# Altium schematic sheet FTDI.SchDoc(FTDI)
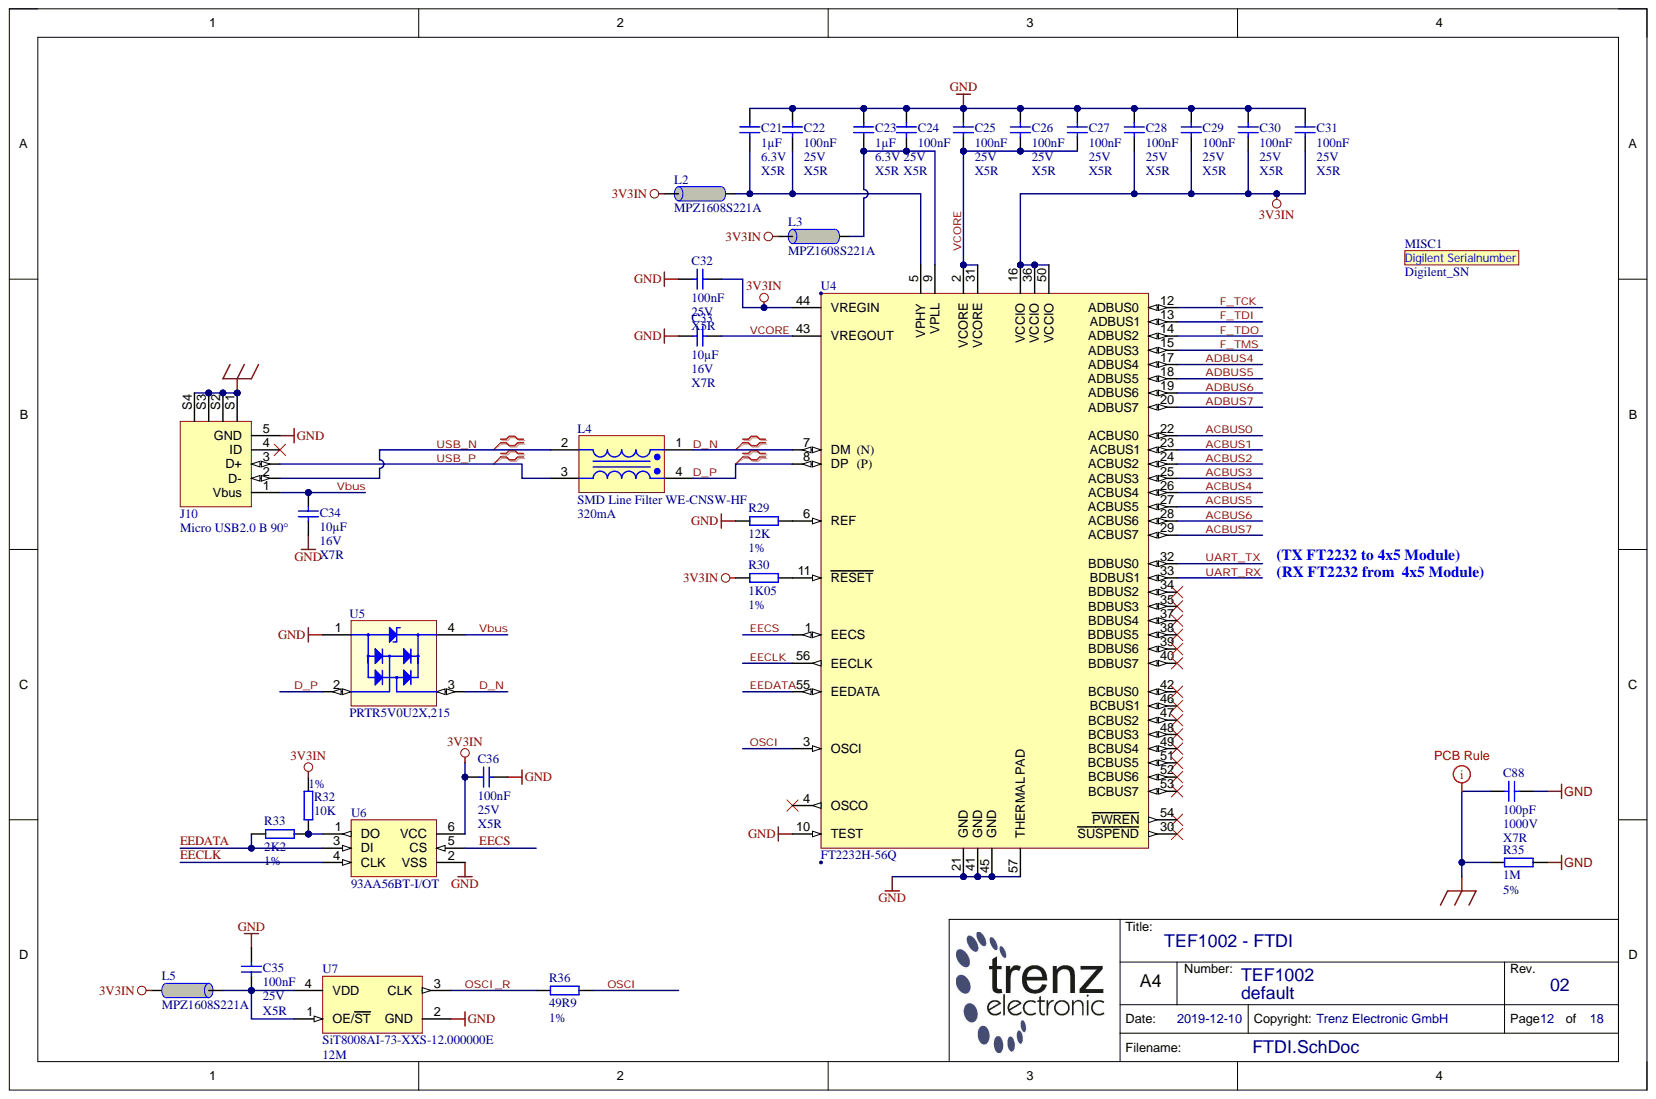
[diagram p0: FTDI.SchDoc]

## Parts
C21  1µF  Technology: X5R  Voltage: 6.3V  [CAPC1005X55N]
C22  100nF  Technology: X5R  Voltage: 25V  [CAPC1005X55N]
C23  1µF  Technology: X5R  Voltage: 6.3V  [CAPC1005X55N]
C24  100nF  Technology: X5R  Voltage: 25V  [CAPC1005X55N]
C25  100nF  Technology: X5R  Voltage: 25V  [CAPC1005X55N]
C26  100nF  Technology: X5R  Voltage: 25V  [CAPC1005X55N]
C27  100nF  Technology: X5R  Voltage: 25V  [CAPC1005X55N]
C28  100nF  Technology: X5R  Voltage: 25V  [CAPC1005X55N]
C29  100nF  Technology: X5R  Voltage: 25V  [CAPC1005X55N]
C30  100nF  Technology: X5R  Voltage: 25V  [CAPC1005X55N]
C31  100nF  Technology: X5R  Voltage: 25V  [CAPC1005X55N]
C32  100nF  [CAPC1005X55N]
C33  10µF  Voltage: 16V  [CAPC2012X135N]
C34  10µF  Technology: X7R  Voltage: 16V  [CAPC2012X135N]
C35  100nF  Voltage: 25V  [CAPC1005X55N]
C36  100nF  Voltage: 25V  [CAPC1005X55N]
C88  100pF  Voltage: 1000V  [CAPC3216X180N]
J10  Micro USB2.0 B 90°  [629105150521]
L2  MPZ1608S221A  [INDC1608X95N]
L3  MPZ1608S221A  [INDC1608X95N]
L4  SMD Line Filter WE-CNSW-HF  Voltage: 320mA  [WE-CNSW-HF]
L5  MPZ1608S221A  [INDC1608X95N]
MISC1  Digilent_SN  (mechanical)
R29  12K  [RESC1005X40N]
R30  1K05  Tolerance: 1%  [RESC1005X40N]
R32  10K  Tolerance: 1%  [RESC1005X40N]
R33  2K2  Tolerance: 1%  [RESC1005X40N]
R35  1M  Tolerance: 5%  [RESC3216X65N]
R36  49R9  Tolerance: 1%  [RESC1005X40N]
U4  FT2232H-56Q  [QFN50P800X800-57T610X610N]
U5  PRTR5V0U2X,215  [SOT190P229X114-4N]
U6  93AA56BT-I/OT  [SOT95P280X145-6N]
U7  SiT8008AI-73-XXS-12.000000E  value 12M  Database Table Name: CLK  [2016]

## Nets
3V3IN: C28.2 C29.2 C30.2 C31.2 C32.2 C36.2 L2.2 L3.2 L5.1 R30.1 R32.1 U4.16(VCCIO) U4.36(VCCIO) U4.44(VREGIN) U4.50(VCCIO) U6.6(VCC)
FGND_FT: C88.1 J10.S1 J10.S2 J10.S3 J10.S4 R35.1
GND: C21.1 C22.1 C23.1 C24.1 C25.1 C26.1 C27.1 C28.1 C29.1 C30.1 C31.1 C32.1 C33.1 C34.1 C35.2 C36.1 C88.2 J10.5(GND) R29.1 R35.2 U4.10(TEST) U4.21(GND) U4.41(GND) U4.45(GND) U4.57(THERMAL_PAD) U5.1 U6.2(VSS) U7.2(GND)
ACBUS0: U4.22(ACBUS0)
ACBUS1: U4.23(ACBUS1)
ACBUS2: U4.24(ACBUS2)
ACBUS3: U4.25(ACBUS3)
ACBUS4: U4.26(ACBUS4)
ACBUS5: U4.27(ACBUS5)
ACBUS6: U4.28(ACBUS6)
ACBUS7: U4.29(ACBUS7)
ADBUS4: U4.17(ADBUS4)
ADBUS5: U4.18(ADBUS5)
ADBUS6: U4.19(ADBUS6)
ADBUS7: U4.20(ADBUS7)
D_N: L4.1 U4.7(DM) U5.3
D_P: L4.4 U4.8(DP) U5.2
EECLK: U4.56(EECLK) U6.4(CLK)
EECS: U4.1(EECS) U6.5(CS)
EEDATA: R33.1 U4.55(EEDATA) U6.3(DI)
F_TCK: U4.12(ADBUS0)
F_TDI: U4.13(ADBUS1)
F_TDO: U4.14(ADBUS2)
F_TMS: U4.15(ADBUS3)
OSCI: R36.2 U4.3(OSCI)
OSCI_R: R36.1 U7.3(CLK)
UART_RX: U4.33(BDBUS1)
UART_TX: U4.32(BDBUS0)
USB_N: J10.2(D-) L4.2
USB_P: J10.3(D+) L4.3
Vbus: C34.2 J10.1(Vbus) U5.4
VCORE: C25.2 C26.2 C27.2 C33.2 U4.2(VCORE) U4.31(VCORE) U4.43(VREGOUT)
NetC21_2: C21.2 C22.2 L2.1 U4.5(VPHY)
NetC23_2: C23.2 C24.2 L3.1 U4.9(VPLL)
NetC35_1: C35.1 L5.2 U7.1(OE/ST) U7.4(VDD)
NetR29_2: R29.2 U4.6(REF)
NetR30_2: R30.2 U4.11(RESET)
NetR32_2: R32.2 R33.2 U6.1(DO)

Not connected: J10 4(ID); U4 4(OSCO) 30(SUSPEND) 34(BDBUS2) 35(BDBUS3) 37(BDBUS4) 38(BDBUS5) 39(BDBUS6) 40(BDBUS7) 42(BCBUS0) 46(BCBUS1) 47(BCBUS2) 48(BCBUS3) 49(BCBUS4) 51(BCBUS5) 52(BCBUS6) 53(BCBUS7) 54(PWREN)

## Library data of the parts
C21 C23 = 23453: KON 1µF 6.3V X5R 10% SMD BF 1005 (0402); Database Table Name: C_1005_0402; Mixed: SMT; Preferred: Yes; Temperature-Range: -55/+85; Value: 1u; AN: 23453; Case: 1005 (0402); Component Kind: Standard; Library Name: TE.DbLib; Tolerance: 10%
C22 C24-C32 C35 C36 = 22472: KON 100nF 25V X5R ±10% SMD BF 1005 (0402); Database Table Name: C_1005_0402; Mixed: SMT; Preferred: Yes; Temperature-Range: -55/+85; Value: 100n; AN: 22472; Case: 1005 (0402); Component Kind: Standard; Library Name: TE.DbLib
  C32: Technology: X5R; Voltage: 25V
  C35 C36: Technology: X5R
C33 C34 = 24380: KON 10µF 16V X7R 10% SMD BF 2012 (0805); Database Table Name: C_2012_0805; Mixed: SMT; Preferred: Yes; Temperature-Range: -55/+125; Value: 10u; AN: 24380; Case: 2012 (0805); Component Kind: Standard; Library Name: TE.DbLib; Tolerance: 10%
  C33: Technology: X7R
C88 = 22679: KON 100pF 1000V X7R 10%  BF 3216 (1206); Database Table Name: C_3216_1206; Mixed: SMT; Preferred: Yes; Temperature-Range: -55/+125; Value: 100p; AN: 22679; Case: 3216 (1206); Component Kind: Standard; Library Name: TE.DbLib; Technology: X7R; Tolerance: 10%
J10 = 27235: Micro USB2.0 B Receptacle 90° SMD/THT; Database Table Name: CON_IO; Mixed: SMT; Temperature-Range: -40/+85; AN: 27235; Component Kind: Standard; H-ART: 629105150521; Library Name: TE.DbLib; HelpURL: B:\AD\adlib\datasheet\629105150521
L2 L3 L5 = 22718: Ferritdurchführung MPZ1608S221A; Database Table Name: L_1608_0603; Mixed: SMT; Preferred: Yes; Value: 220 OHm @ 100MHz; AN: 22718; Case: 1608 (0603); Component Kind: Standard; H-ART: MPZ1608S221A; Library Name: TE.DbLib; Trenz-Stock: Yes; HelpURL: B:\AD\adlib\datasheet\MPZ1608
L4 = 24514: Stromkompensierter SMD Line Filter HF 320mA 0,24Ohm 50V; Database Table Name: L_SMD; H-ART: 744233670; Mixed: SMT; Preferred: Yes; AN: 24514; Component Kind: Standard; Library Name: TE.DbLib; Technology: 0.24Ohm; Trenz-Stock: Yes; HelpURL: B:\AD\adlib\datasheet\WE-CNSW HF
MISC1 = 27065: Digilent Serialnumber; Database Table Name: PCB; AN: 27065; Library Name: TE.DbLib
R29 = 22598: WID SMD 12K 1% 0,063W  BF 1005 (0402); Database Table Name: R_1005_0402; Mixed: SMT; Preferred: Yes; AN: 22598; Case: 1005 (0402); Component Kind: Standard; Library Name: TE.DbLib; Technology: TK100; Tolerance: 1%; Value: 12K
R30 = 25956: WID SMD 1K05 1% 0,063W  BF 1005 (0402); Database Table Name: R_1005_0402; Mixed: SMT; Value: 1.05K; AN: 25956; Case: 1005 (0402); Component Kind: Standard; Library Name: TE.DbLib; Technology: TK100
R32 = 22750: WID SMD 10K 1% 0,063W BF 1005 (0402); Database Table Name: R_1005_0402; H-ART: RC0402FR-0710KL; Mixed: SMT; Preferred: Yes; AN: 22750; Case: 1005 (0402); Component Kind: Standard; Library Name: TE.DbLib; Technology: TK100; Value: 10K
R33 = 22748: WID SMD 2K2 1% 0,063W BF 1005 (0402); Database Table Name: R_1005_0402; H-ART: RC0402FR-132K2L; Mixed: SMT; Preferred: Yes; Value: 2.2K; AN: 22748; Case: 1005 (0402); Component Kind: Standard; Library Name: TE.DbLib; Technology: TK100
R35 = 22757: WID SMD 1M 5% 0,25W  BF 3216 (1206); Database Table Name: R_3216_1206; Mixed: SMT; Preferred: Yes; AN: 22757; Case: 3216 (1206); Component Kind: Standard; Library Name: TE.DbLib; Technology: TK100; Value: 1M
R36 = 23135: WID SMD 49R9 1% 0,063W  BF 1005 (0402); Database Table Name: R_1005_0402; H-ART: RC0402FR-0749R9L; Mixed: SMT; Preferred: Yes; Value: 49.9; AN: 23135; Case: 1005 (0402); Component Kind: Standard; Library Name: TE.DbLib; Technology: TK100
U4 = 27277: Database Table Name: IF; Mixed: SMT; Preferred: Yes; Temperature-Range: -40/+85; AN: 27277; Case: VQFN56; Component Kind: Standard; Description: FT2232H-56Q; H-ART: FT2232H-56Q; Library Name: TE.DbLib; Storage-Temperature: -65/+150(Abs); Trenz-Stock: Yes; HelpURL: B:\AD\adlib\datasheet\FT2232H
U5 = 24840: Database Table Name: DIODE; Mixed: SMT; Preferred: Yes; Temperature-Range: -40/+85; AN: 24840; Case: SOT143; Component Kind: Standard; Description: PRTR5V0U2X,215; H-ART: PRTR5V0U2X,215; Library Name: TE.DbLib; HelpURL: B:\AD\adlib\datasheet\CD143A-SR05
U6 = 24730: Database Table Name: EEPROM; Mixed: SMT; Preferred: Yes; Temperature-Range: -40/+85; AN: 24730; Case: SOT23-6; Component Kind: Standard; Description: 93AA56BT-I/OT; H-ART: 93AA56BT-I/OT; Library Name: TE.DbLib; Trenz-Stock: Yes; HelpURL: B:\AD\adlib\datasheet\93AA56A
U7 = 25325: SiT8008AI-73-XXS-12.000000E MEMS Oszillator 12MHz; Mixed: SMT; Preferred: Yes; Temperature-Range: -40/+85; AN: 25325; Case: 2.0  x 1.6mm; Component Kind: Standard; H-ART: SiT8008AI-73-XXS-12.000000E; Library Name: TE.DbLib; Trenz-Stock: Yes; HelpURL: B:\AD\adlib\datasheet\SiT8008
